# Altium schematic sheet PWR.SchDoc
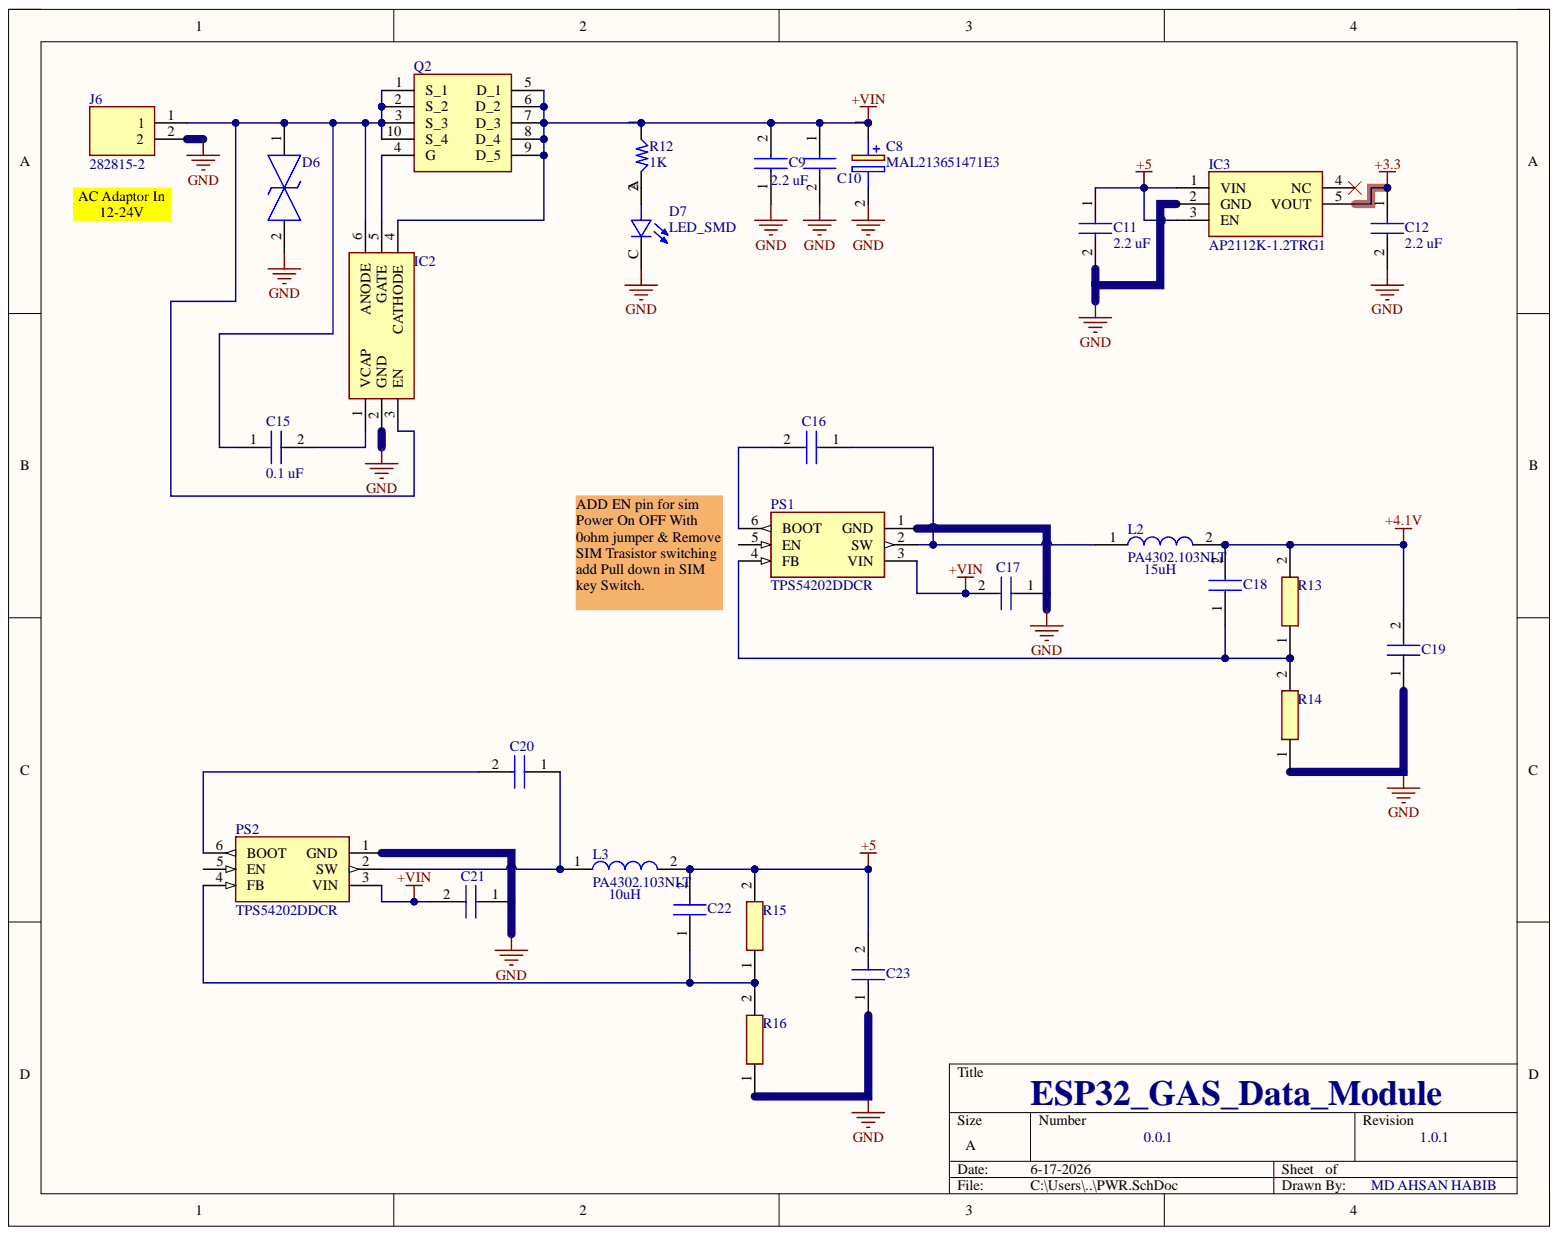
[diagram p0: PWR.SchDoc]

## Parts
C8  MAL213651471E3  [CAPPRD500W60D1300H2700]
C9  2.2 uF  [CAP0603]
C10  C5750X5R1H106K230KA  [C5750_Commercial]  (value not drawn)
C11  2.2 uF  [CAP0603]
C12  2.2 uF  [CAP0603]
C15  0.1 uF  [CAP0603]
C16  C0805C104M5RAC-TU  [CAPC2012X88N]  (value not drawn)
C17  C5750X5R1H106K230KA  [CAP0603]  (value not drawn)
C18  GRM0335C1E560JA01D  [CAP0603]  (value not drawn)
C19  GRM32ER61C476KE15L  [CAPC3225X270N]  (value not drawn)
C20  C0805C104M5RAC-TU  [CAPC2012X88N]  (value not drawn)
C21  C5750X5R1H106K230KA  [CAP0603]  (value not drawn)
C22  CGA1A2C0G1E820J030BA  [CAP0603]  (value not drawn)
C23  GRM32ER61C476KE15L  [CAPC3225X270N]  (value not drawn)
D6  SMBJ24CA-13-F  [DIONM5336X250N]  (value not drawn)
D7  LED_SMD  [LEDSC125X200X90-2N]
IC2  LM74700QDBVTQ1  [SOT95P280X145-6N]  (value not drawn)
IC3  AP2112K-1.2TRG1  [SOT95P285X140-5N]
J6  282815-2  [SHDRRA2W102P0X508_1X2_1016X1200X850P]
L2  PA4302.103NLT  [PA4302103NLT]
L3  PA4302.103NLT  [PA4302103NLT]
PS1  TPS54202DDCR  [SOT95P280X110-6N]
PS2  TPS54202DDCR  [SOT95P280X110-6N]
Q2  BSC014N06NSATMA1  [BSC010N04LS6ATMA1]  (value not drawn)
R12  1K  [RES0603]
R13  CRCW0402100KFKEDHP  [RES0603]  (value not drawn)
R14  CRCW040216K9FKEDHP  [RES0603]  (value not drawn)
R15  CRCW0402100KFKEDHP  [RES0603]  (value not drawn)
R16  CRCW040213K7FKEDHP  [RES0603]  (value not drawn)

## Nets
+3.3: C12.1 IC3.5(VOUT)
+4.1V: C18.2 C19.2 L2.2 R13.2
+5: C11.1 C22.2 C23.2 IC3.1(VIN) IC3.3(EN) L3.2 R15.2
+VIN: C8.1 C9.2 C10.1 C17.2 C21.2 IC2.4(CATHODE) PS1.3(VIN) PS2.3(VIN) Q2.5(D_1) Q2.6(D_2) Q2.7(D_3) Q2.8(D_4) Q2.9(D_5) R12.1
GND: C8.2 C9.1 C10.2 C11.2 C12.2 C17.1 C19.1 C21.1 C23.1 D6.2 D7.C IC2.2(GND) IC3.2(GND) J6.2 PS1.1(GND) PS2.1(GND) R14.1 R16.1
NetC15_1: C15.1 D6.1 IC2.3(EN) IC2.6(ANODE) J6.1 Q2.1(S_1) Q2.2(S_2) Q2.3(S_3) Q2.10(S_4)
NetC15_2: C15.2 IC2.1(VCAP)
NetC16_1: C16.1 L2.1 PS1.2(SW)
NetC16_2: C16.2 PS1.6(BOOT)
NetC18_1: C18.1 PS1.4(FB) R13.1 R14.2
NetC20_1: C20.1 L3.1 PS2.2(SW)
NetC20_2: C20.2 PS2.6(BOOT)
NetC22_1: C22.1 PS2.4(FB) R15.1 R16.2
NetD7_A: D7.A R12.2
NetIC2_5: IC2.5(GATE) Q2.4(G)

Not connected: IC3 4(NC); PS1 5(EN); PS2 5(EN)

## Library data of the parts
C8 = MAL213651471E3: Capacitor Polarised; Manufacturer_Name: Vishay; Component Kind: Standard; Datasheet Link: https://www.mouser.co.uk/datasheet/2/427/136rvi-1762300.pdf; Height: 27mm; Manufacturer_Part_Number: MAL213651471E3; Mouser Part Number: 594-2222-136-51471; Mouser Price/Stock: https://www.mouser.co.uk/ProductDetail/Vishay-BC-Components/MAL213651471E3?qs=zGH8QOQ14qXx9%252BWDTpPwWA%3D%3D; Library Name: Valve_Controller_Lib.IntLib
C9 C11 C12 C15 = CC0603KRX7R9BB104: Capacitor; Manufacturer_Name: YAGEO; Arrow Part Number: CC0603KRX7R9BB104; Arrow Price/Stock: https://www.arrow.com/en/products/cc0603krx7r9bb104/yageo?utm_currency=USD&region=europe; Component Kind: Standard; Datasheet Link: https://componentsearchengine.com/Datasheets/2/CC0603KRX7R9BB104.pdf; Height: 0.9mm; Manufacturer_Part_Number: CC0603KRX7R9BB104; Mouser Part Number: 603-CC603KRX7R9BB104; Mouser Price/Stock: https://www.mouser.co.uk/ProductDetail/YAGEO/CC0603KRX7R9BB104?qs=vTakOoo5QyLvVCYM2ge8LQ%3D%3D; Library Name: Valve_Controller_Lib.IntLib
C10 C17 C21 = C5750X5R1H106K230KA: Capacitor; Manufacturer_Name: TDK; Arrow Part Number: C5750X5R1H106K230KA; Arrow Price/Stock: https://www.arrow.com/en/products/c5750x5r1h106k230ka/tdk?region=nac; Component Kind: Standard; Datasheet Link: https://product.tdk.com/system/files/dam/doc/product/capacitor/ceramic/mlcc/catalog/mlcc_commercial_general_en.pdf; Height: 2.5mm; Manufacturer_Part_Number: C5750X5R1H106K230KA; Mouser Part Number: 810-C5750X5R1H106K; Mouser Price/Stock: https://www.mouser.co.uk/ProductDetail/TDK/C5750X5R1H106K230KA?qs=NRhsANhppD8lVYAvl0MZ3g%3D%3D; Library Name: Valve_Controller_Lib.IntLib
C16 C20 = C0805C104M5RAC-TU: Capacitor; Manufacturer_Name: Yageo Group; Arrow Part Number: C0805C104M5RAC-TU; Arrow Price/Stock: https://www.arrow.com/en/products/c0805c104m5ractu/kemet-corporation?region=nac; Component Kind: Standard; Datasheet Link: https://connect.kemet.com:7667/gateway/IntelliData-ComponentDocumentation/1.0/download/specsheet/C0805C104M5RACTU; Height: 0.88mm; Manufacturer_Part_Number: C0805C104M5RAC-TU; Library Name: Valve_Controller_Lib.IntLib
C18 = GRM0335C1E560JA01D: Capacitor; Manufacturer_Name: Murata Electronics; Arrow Part Number: GRM0335C1E560JA01D; Arrow Price/Stock: https://www.arrow.com/en/products/grm0335c1e560ja01d/murata-manufacturing; Component Kind: Standard; Height: 0.33mm; Manufacturer_Part_Number: GRM0335C1E560JA01D; Mouser Part Number: 81-GRM0335C1E560JA1D; Mouser Price/Stock: https://www.mouser.com/Search/Refine.aspx?Keyword=81-GRM0335C1E560JA1D; Library Name: Valve_Controller_Lib.IntLib
C19 C23 = GRM32ER61C476KE15L: Capacitor; Manufacturer_Name: Murata Electronics; Arrow Part Number: GRM32ER61C476KE15L; Arrow Price/Stock: https://www.arrow.com/en/products/grm32er61c476ke15l/murata-manufacturing?utm_currency=USD&region=europe; Component Kind: Standard; Datasheet Link: https://search.murata.co.jp/Ceramy/image/img/A01X/G101/ENG/GRM32ER61C476KE15-01A.pdf; Height: 2.7mm; Manufacturer_Part_Number: GRM32ER61C476KE15L; Mouser Part Number: 81-GRM32ER61C476KE5L; Mouser Price/Stock: https://www.mouser.co.uk/ProductDetail/Murata-Electronics/GRM32ER61C476KE15L?qs=%252B%2F7tQhzlcy7Im%2F1Gh2YSGQ%3D%3D; Library Name: Valve_Controller_Lib.IntLib
C22 = CGA1A2C0G1E820J030BA: Capacitor; Manufacturer_Name: TDK; Component Kind: Standard; Datasheet Link: https://product.tdk.com/system/files/dam/doc/product/capacitor/ceramic/mlcc/catalog/mlcc_automotive_general_en.pdf; Height: 0.33mm; Manufacturer_Part_Number: CGA1A2C0G1E820J030BA
D6 = SMBJ24CA-13-F: TVS Diode (Bi-directional); Manufacturer_Name: Diodes Incorporated; Arrow Part Number: SMBJ24CA-13-F; Arrow Price/Stock: https://www.arrow.com/en/products/smbj24ca-13-f/diodes-incorporated?utm_currency=USD&region=nac; Component Kind: Standard; Datasheet Link: https://www.diodes.com/assets/Datasheets/ds19002.pdf; Height: 2.5mm; Manufacturer_Part_Number: SMBJ24CA-13-F; Mouser Part Number: 621-SMBJ24CA-13-F; Mouser Price/Stock: https://www.mouser.co.uk/ProductDetail/Diodes-Incorporated/SMBJ24CA-13-F?qs=gaDBXWSqsDCkhBi9r6ReOQ%3D%3D
D7 = 5990110007F: Red 633nm LED Indication - Discrete 2.1V 0805 (2012 Metric); MF: Dialight; MP: 599-0110-007F; Availability: In Stock; Check_prices: https://www.snapeda.com/parts/599-0110-007F/Dialight/view-part/?ref=eda; Component Kind: Standard; Package: 0805 Dialight; Price: None; Purchase-URL: https://pricing.snapeda.com/search/part/599-0110-007F/?ref=eda; SnapEDA_Link: https://www.snapeda.com/parts/599-0110-007F/Dialight/view-part/?ref=snap; Library Name: Valve_Controller_Lib.IntLib
IC2 = LM74700-Q1MKX-1_NOPB: Integrated Circuit; Manufacturer_Name: Texas Instruments; Manufacturer_Part_Number: LM74700-Q1MKX-1/NOPB; Component Kind: Standard; Datasheet Link: http://www.ti.com/lit/ds/symlink/lm74700-q1.pdf; Height: 1.45mm
IC3 = AP2112K-1.2TRG1: Integrated Circuit; Manufacturer_Name: Diodes Incorporated; Arrow Part Number: AP2112K-1.2TRG1; Arrow Price/Stock: https://www.arrow.com/en/products/ap2112k-1.2trg1/diodes-incorporated.html?utm_currency=USD&region=nac; Component Kind: Standard; Datasheet Link: https://www.diodes.com/assets/Datasheets/AP2112.pdf; Height: 1.4mm; Manufacturer_Part_Number: AP2112K-1.2TRG1; Mouser Part Number: 621-AP2112K-1.2TRG1; Mouser Price/Stock: https://www.mouser.co.uk/ProductDetail/Diodes-Incorporated/AP2112K-1.2TRG1?qs=dZoB6MK9LKOx%252Blz%2FhgH2SA%3D%3D
J6 = 282815-2: Connector; Manufacturer_Name: TE Connectivity; Arrow Part Number: 282815-2; Arrow Price/Stock: https://www.arrow.com/en/products/282815-2/te-connectivity?region=nac; Component Kind: Standard; Datasheet Link: https://www.te.com/commerce/DocumentDelivery/DDEController?Action=srchrtrv&DocNm=1-773958-1&DocType=Data%20Sheet&DocLang=English&PartCntxt=282815-2&DocFormat=pdf; Height: 8.5mm; Manufacturer_Part_Number: 282815-2; Mouser Part Number: 571-282815-2; Mouser Price/Stock: https://www.mouser.co.uk/ProductDetail/TE-Connectivity/282815-2?qs=79%2FjLZ2kXkpF7MFURi982A%3D%3D
L2 L3 = PA4302.103NLT: Inductor; Manufacturer_Name: Yageo Group; Arrow Part Number: PA4302.103NLT; Arrow Price/Stock: https://www.arrow.com/en/products/pa4302.103nlt/pulse-electronics-corporation?region=nac; Component Kind: Standard; Datasheet Link: https://www.mouser.com/datasheet/2/336/-559356.pdf; Height: 5.6mm; Manufacturer_Part_Number: PA4302.103NLT; Mouser Part Number: 673-PA4302.103NLT; Mouser Price/Stock: https://www.mouser.co.uk/ProductDetail/Pulse-Electronics/PA4302.103NLT?qs=ZU1dyKYMvyPXoPwo6qMOJg%3D%3D
PS1 PS2 = TPS54202DDCR: Power Supply; Manufacturer_Name: Texas Instruments; Arrow Part Number: TPS54202DDCR; Arrow Price/Stock: https://www.arrow.com/en/products/tps54202ddcr/texas-instruments?utm_currency=USD&region=nac; Component Kind: Standard; Datasheet Link: http://www.ti.com/lit/gpn/tps54202; Height: 1.1mm; Manufacturer_Part_Number: TPS54202DDCR; Mouser Part Number: 595-TPS54202DDCR; Mouser Price/Stock: https://www.mouser.co.uk/ProductDetail/Texas-Instruments/TPS54202DDCR?qs=uP22XH7NdCsJtN%2Fq54jCtQ%3D%3D; Library Name: Valve_Controller_Lib.IntLib
Q2 = BSC014N06NSATMA1: MOSFET (N-Channel); Manufacturer_Name: Infineon; Arrow Part Number: BSC014N06NSATMA1; Arrow Price/Stock: https://www.arrow.com/en/products/bsc014n06nsatma1/infineon-technologies-ag?region=nac; Component Kind: Standard; Datasheet Link: http://www.farnell.com/datasheets/1849651.html; Height: 1.1mm; Manufacturer_Part_Number: BSC014N06NSATMA1; Mouser Part Number: 726-BSC014N06NSATMA1; Mouser Price/Stock: https://www.mouser.co.uk/ProductDetail/Infineon-Technologies/BSC014N06NSATMA1?qs=K00xGehIljshgUA78G26tg%3D%3D; Library Name: Valve_Controller_Lib.IntLib
R12 = RES: Resistor; Manufacturer_Name: UNI-ROYAL(Uniroyal Elec); Manufacturer_Part_Number: 0603WAF1001T5E; Component Kind: Standard; Datasheet Link: https://datasheet.lcsc.com/szlcsc/Uniroyal-Elec-0603WAF1001T5E_C21190.pdf; Height: 0.55mm; Library Name: Valve_Controller_Lib.IntLib
R13 R15 = CRCW0402100KFKEDHP: Resistor; Manufacturer_Name: Vishay; Arrow Part Number: CRCW0402100KFKEDHP; Arrow Price/Stock: https://www.arrow.com/en/products/crcw0402100kfkedhp/vishay?utm_currency=USD&region=nac; Component Kind: Standard; Datasheet Link: http://www.vishay.com/docs/20035/dcrcwe3.pdf; Height: 0.4mm; Manufacturer_Part_Number: CRCW0402100KFKEDHP; Mouser Part Number: 71-CRCW0402100KFKEDH; Mouser Price/Stock: https://www.mouser.co.uk/ProductDetail/Vishay-Dale/CRCW0402100KFKEDHP?qs=k2%2FDWSARqgGFakjlJe4Ejw%3D%3D; Library Name: Valve_Controller_Lib.IntLib
R14 = CRCW040216K9FKEDHP: Resistor; Manufacturer_Name: Vishay; Component Kind: Standard; Datasheet Link: http://www.vishay.com/docs/20035/dcrcwe3.pdf; Height: 0.4mm; Manufacturer_Part_Number: CRCW040216K9FKEDHP; Mouser Part Number: 71-CRCW040216K9FKEDH; Mouser Price/Stock: https://www.mouser.com/Search/Refine.aspx?Keyword=71-CRCW040216K9FKEDH; Library Name: Valve_Controller_Lib.IntLib
R16 = CRCW040213K7FKEDHP: Resistor; Manufacturer_Name: Vishay; Arrow Part Number: CRCW040213K7FKEDHP; Arrow Price/Stock: https://www.arrow.com/en/products/crcw040213k7fkedhp/vishay?region=nac; Component Kind: Standard; Datasheet Link: http://www.vishay.com/docs/20035/dcrcwe3.pdf; Height: 0.4mm; Manufacturer_Part_Number: CRCW040213K7FKEDHP; Mouser Part Number: N/A; Mouser Price/Stock: https://www.mouser.co.uk/ProductDetail/Vishay-Dale/CRCW040213K7FKEDHP?qs=VAB4DzKv5wWcbGmoe9QIoA%3D%3D
